# Altium schematic sheet doan2.SchDoc
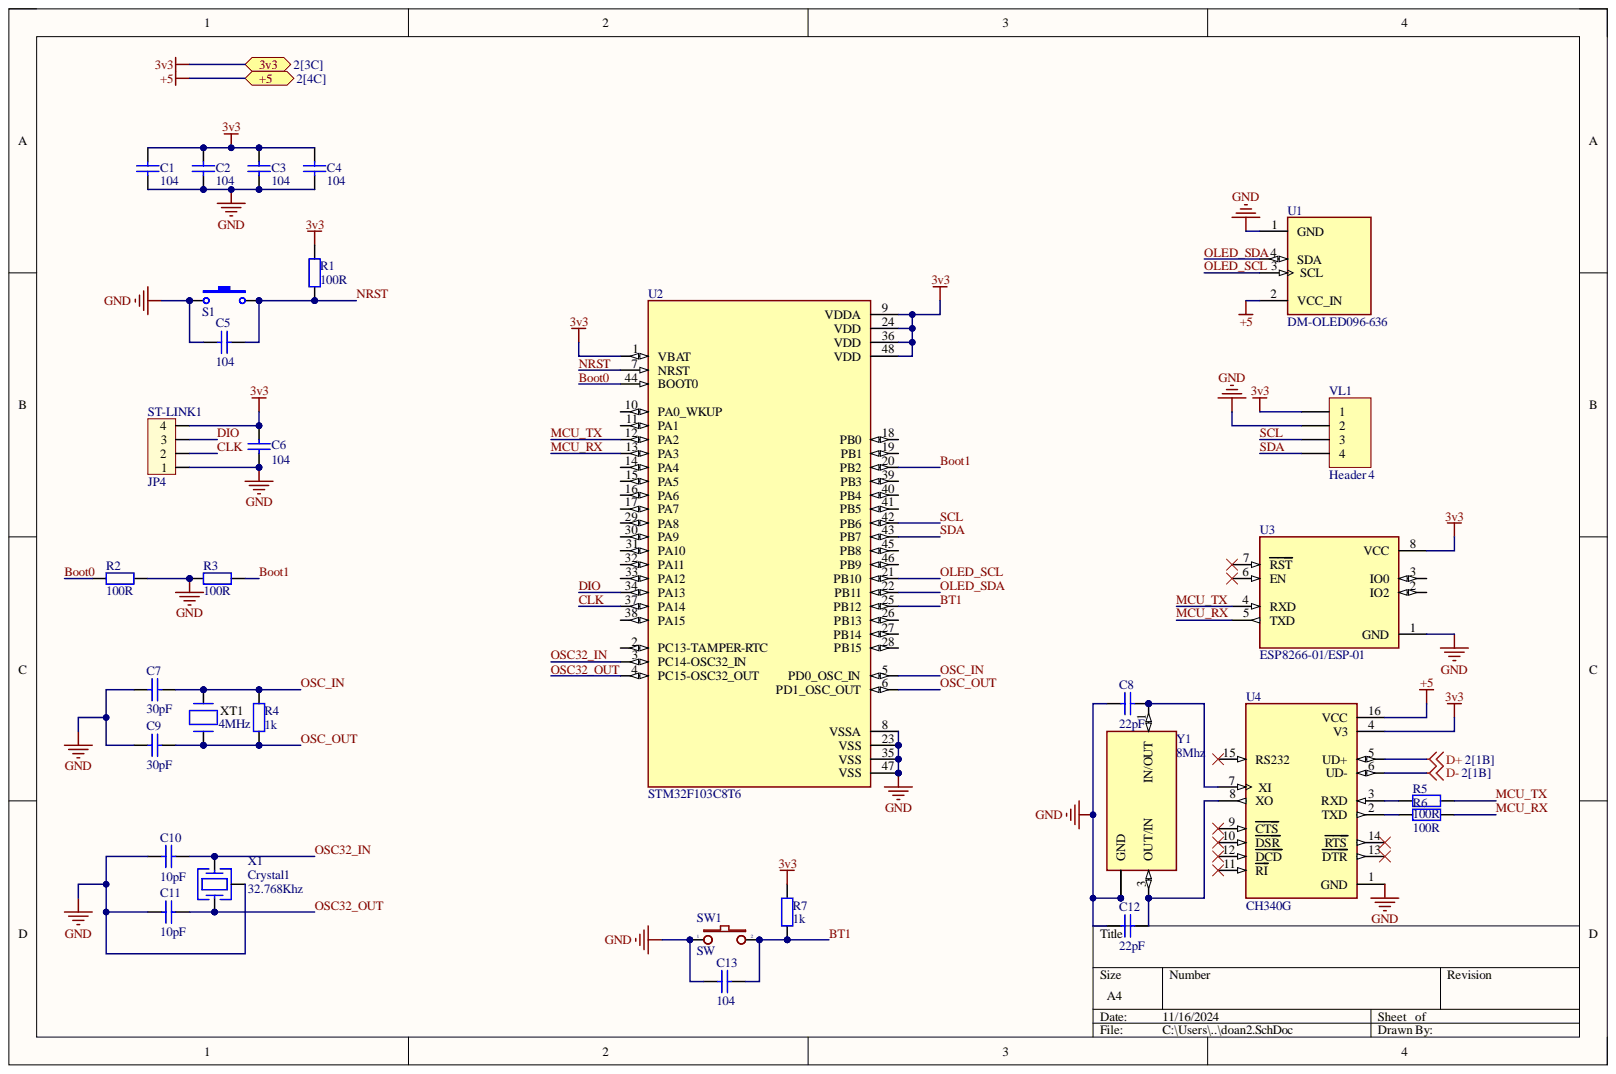
[diagram p0: doan2.SchDoc]

## Parts
C1  value 104  [C-NP-0805]  (hidden comment: Cap Non-pol)
C2  value 104  [C-NP-0805]  (hidden comment: Cap Non-pol)
C3  value 104  [C-NP-0805]  (hidden comment: Cap Non-pol)
C4  value 104  [C-NP-0805]  (hidden comment: Cap Non-pol)
C5  value 104  [C-NP-0805]  (hidden comment: Cap Non-pol)
C6  value 104  [C-NP-0805]  (hidden comment: Cap Non-pol)
C7  value 30pF  [C-NP-0805]  (hidden comment: Cap Non-pol)
C8  value 22pF  [C-NP-0805]  (hidden comment: Cap Non-pol)
C9  value 30pF  [C-NP-0805]  (hidden comment: Cap Non-pol)
C10  value 10pF  [C-NP-0805]  (hidden comment: Cap Non-pol)
C11  value 10pF  [C-NP-0805]  (hidden comment: Cap Non-pol)
C12  value 22pF  [C-NP-0805]  (hidden comment: Cap Non-pol)
C13  value 104  [C-NP-0805]  (hidden comment: Cap Non-pol)
R1  value 100R  [DIEN TRO 0805]  (hidden comment: RES_SMD_0805)
R2  value 100R  [DIEN TRO 0805]  (hidden comment: RES_SMD_0805)
R3  value 100R  [DIEN TRO 0805]  (hidden comment: RES_SMD_0805)
R4  value 1k  [DIEN TRO 0805]  (hidden comment: RES_SMD_0805)
R5  value 100R  [DIEN TRO 0805]  (hidden comment: RES_SMD_0805)
R6  value 100R  [DIEN TRO 0805]  (hidden comment: RES_SMD_0805)
R7  value 1k  [DIEN TRO 0805]  (hidden comment: RES_SMD_0805)
S1  SW-SMD  [SW-SMD]  (value not drawn)
ST-LINK1  JP4  [CON4]
SW1  SW  [SW2]
U1  DM-OLED096-636  [MODULE_DM-OLED096-636]
U2  STM32F103C8T6  [QFP50P900X900X160-48N]
U3  ESP8266-01/ESP-01  [XCVR_ESP8266-01/ESP-01]
U4  CH340G  [SOIC127P600X180-16N]
VL1  Header 4  [Header 4]
X1  Crystal1  value 32.768Khz  [Crystal cylinder]
XT1  value 4MHz  [Crystal.HC49/USxx]  (hidden comment: Crystal2)
Y1  8Mhz  [XTAL_ECS-250-6-33B2-CTN-TR3]

## Ports
3v3, +5

## Nets
D+: U4.5(UD+)
D-: U4.6(UD-)
GND: C1.1 C2.1 C3.1 C4.1 C5.2 C6.2 C7.2 C8.2 C9.2 C10.2 C11.2 C12.2 C13.2 R2.2 R3.1 S1.1 ST-LINK1.1 SW1.1 U1.1(GND) U2.8(VSSA) U2.23(VSS) U2.35(VSS) U2.47(VSS) U3.1(GND) U4.1(GND) VL1.2 X1.3 Y1.2(GND) Y1.4
3v3: C1.2 C2.2 C3.2 C4.2 C6.1 R1.2 R7.2 ST-LINK1.4 U2.1(VBAT) U2.9(VDDA) U2.24(VDD) U2.36(VDD) U2.48(VDD) U3.8(VCC) U4.4(V3) VL1.1 ; port 3v3
+5: U1.2(VCC_IN) U4.16(VCC) ; port +5
Boot0: R2.1 U2.44(BOOT0)
Boot1: R3.2 U2.20(PB2)
BT1: C13.1 R7.1 SW1.2 U2.25(PB12)
CLK: ST-LINK1.2 U2.37(PA14)
DIO: ST-LINK1.3 U2.34(PA13)
MCU_RX: R6.2 U2.13(PA3) U3.5(TXD)
MCU_TX: R5.2 U2.12(PA2) U3.4(RXD)
NRST: C5.1 R1.1 S1.2 U2.7(NRST)
OLED_SCL: U1.3(SCL) U2.21(PB10)
OLED_SDA: U1.4(SDA) U2.22(PB11)
OSC32_IN: C10.1 U2.3(PC14-OSC32_IN) X1.2
OSC32_OUT: C11.1 U2.4(PC15-OSC32_OUT) X1.1
OSC_IN: C7.1 R4.2 U2.5(PD0_OSC_IN) XT1.2
OSC_OUT: C9.1 R4.1 U2.6(PD1_OSC_OUT) XT1.1
SCL: U2.42(PB6) VL1.3
SDA: U2.43(PB7) VL1.4
NetC8_1: C8.1 U4.7(XI) Y1.1(IN/OUT)
NetC12_1: C12.1 U4.8(XO) Y1.3(OUT/IN)
NetR5_1: R5.1 U4.3(RXD)
NetR6_1: R6.1 U4.2(TXD)

Not connected: U2 2(PC13-TAMPER-RTC) 10(PA0_WKUP) 11(PA1) 14(PA4) 15(PA5) 16(PA6) 17(PA7) 18(PB0) 19(PB1) 26(PB13) 27(PB14) 28(PB15) 29(PA8) 30(PA9) 31(PA10) 32(PA11) 33(PA12) 38(PA15) 39(PB3) 40(PB4) 41(PB5) 45(PB8) 46(PB9); U3 2(IO2) 3(IO0) 6(EN) 7(RST); U4 9(CTS) 10(DSR) 11(RI) 12(DCD) 13(DTR) 14(RTS) 15(RS232)

## Library data of the parts
C1-C13 = Cap Non-pol: Non-polarized Capacitor; Component Kind: Standard; LatestRevisionDate: 17-Jul-2002; LatestRevisionNote: Re-released for DXP Platform.; PackageReference: RAD-0.3; Published: 8-Jun-2000; Library Name: Kiet.IntLib; Publisher: Altium Limited
R1-R7 = RES_SMD_0805: RES_0805; Component Kind: Standard; LatestRevisionDate: 17-Jul-2002; LatestRevisionNote: Re-released for DXP Platform.; PackageReference: AXIAL-0.4; Published: 8-Jun-2000; Signal Integrity: Res; Simulation: RESISTOR; Library Name: Kiet.IntLib; Publisher: Altium Limited
S1 = SW-SMD: Switch; Component Kind: Standard; LatestRevisionDate: 17-Jul-2002; LatestRevisionNote: Re-released for DXP Platform.; PackageReference: SPST-2; Published: 24-Mar-1999; Library Name: Kiet.IntLib; Publisher: Altium Limited
ST-LINK1 = Con4: Connector 4; Component Kind: Standard; Value: Connector 4; Library Name: Kiet.IntLib
SW1 = SW2: Switch button 2 pin; Value: SW chip 2 pin; Component Kind: Standard; Library Name: Kiet.IntLib
U1 = DM-OLED096-636: 0.96â€ 128 X 64 MONOCHROME GRAPHIC OLED DISPLAY MODULE - I2C; Check_prices: https://www.snapeda.com/parts/DM-OLED096-636/Display+Module/view-part/?ref=eda; Component Kind: Standard; Library Name: DM-OLED096-636.IntLib; MF: Display Module; MP: DM-OLED096-636; Package: Package; SnapEDA_Link: https://www.snapeda.com/parts/DM-OLED096-636/Display+Module/view-part/?ref=snap
U2 = STM32F103C8T6: ARM® Cortex®-M3 STM32F1 Microcontroller IC 32-Bit 72MHz 64KB (64K x 8) FLASH 48-LQFP (7x7); MF: STMicroelectronics; Check_prices: https://www.snapeda.com/parts/STM32F103C8T6/STMicroelectronics/view-part/?ref=eda; Component Kind: Standard; Library Name: STM32F103C8T6.IntLib; MP: STM32F103C8T6; Package: LQFP-48 STMicroelectronics; SnapEDA_Link: https://www.snapeda.com/parts/STM32F103C8T6/STMicroelectronics/view-part/?ref=snap
U3 = ESP8266-01/ESP-01: MakerFocus 4pcs ESP8266 ESP-01 Serial Wireless WiFi Transceiver Receiver Module 1MB SPI Flash DC3.0-3.6V Internet of Things WiFi Module Board Compatible with Ar duino; Availability: Unavailable; Component Kind: Standard; Library Name: ESP8266-01_ESP-01.IntLib; MP: ESP8266-01/ESP-01; Package: None; Price: None
U4 = CH340G: USB Interface Integrated Circuit; MF: WCH; Availability: Not in stock; Check_prices: https://www.snapeda.com/parts/Ch340G/WCH/view-part/?ref=eda; Component Kind: Standard; Library Name: CH340G.IntLib; MP: Ch340G; Package: None; Price: None; SnapEDA_Link: https://www.snapeda.com/parts/Ch340G/WCH/view-part/?ref=snap
VL1 = Header 4: Header, 4-Pin; Component Kind: Standard; LatestRevisionDate: 17-Jul-2002; LatestRevisionNote: Re-released for DXP Platform.; Library Name: Kiet.IntLib; Publisher: Altium Limited
X1 = Crystal1: Tuning Forl Crystal Units (SMD-Cylinder Type); Vendor: Digikey; Component Kind: Standard; ComponentLink1Description: Digikey Part Link; ComponentLink1URL: http://search.digikey.com/scripts/DkSearch/dksus.dll?Detail&name=300-2066-1-ND; ComponentLink2Description: Open Datasheet; Published: 13-April-2009; ComponentLink2URL: H:\LIBRARY\LIBRARY.Datasheet\k-cmr.pdf; Library Name: Kiet.IntLib; Publisher: Hoang Nguyen
XT1 = Crystal2: Quarz Crystal; Vendor: Digikey; Component Kind: Standard; ComponentLink1Description: Digikey Part Link; ComponentLink2Description: Open Datasheet; Price: 2000 VND; Published: 13-April-2009; ComponentLink2URL: H:\LIBRARY\LIBRARY.Datasheet\; Library Name: Kiet.IntLib; Publisher: Hoang Nguyen
Y1 = ECS-250-6-33B2-CTN-TR3: Availability: Unavailable; Component Kind: Standard; Library Name: ECS-250-6-33B2-CTN-TR3.IntLib; MP: ECS-250-6-33B2-CTN-TR3; Package: None; Price: None
